# Altium schematic sheet Connectors_Peripherals.SchDoc(Connectors_Peripherals)
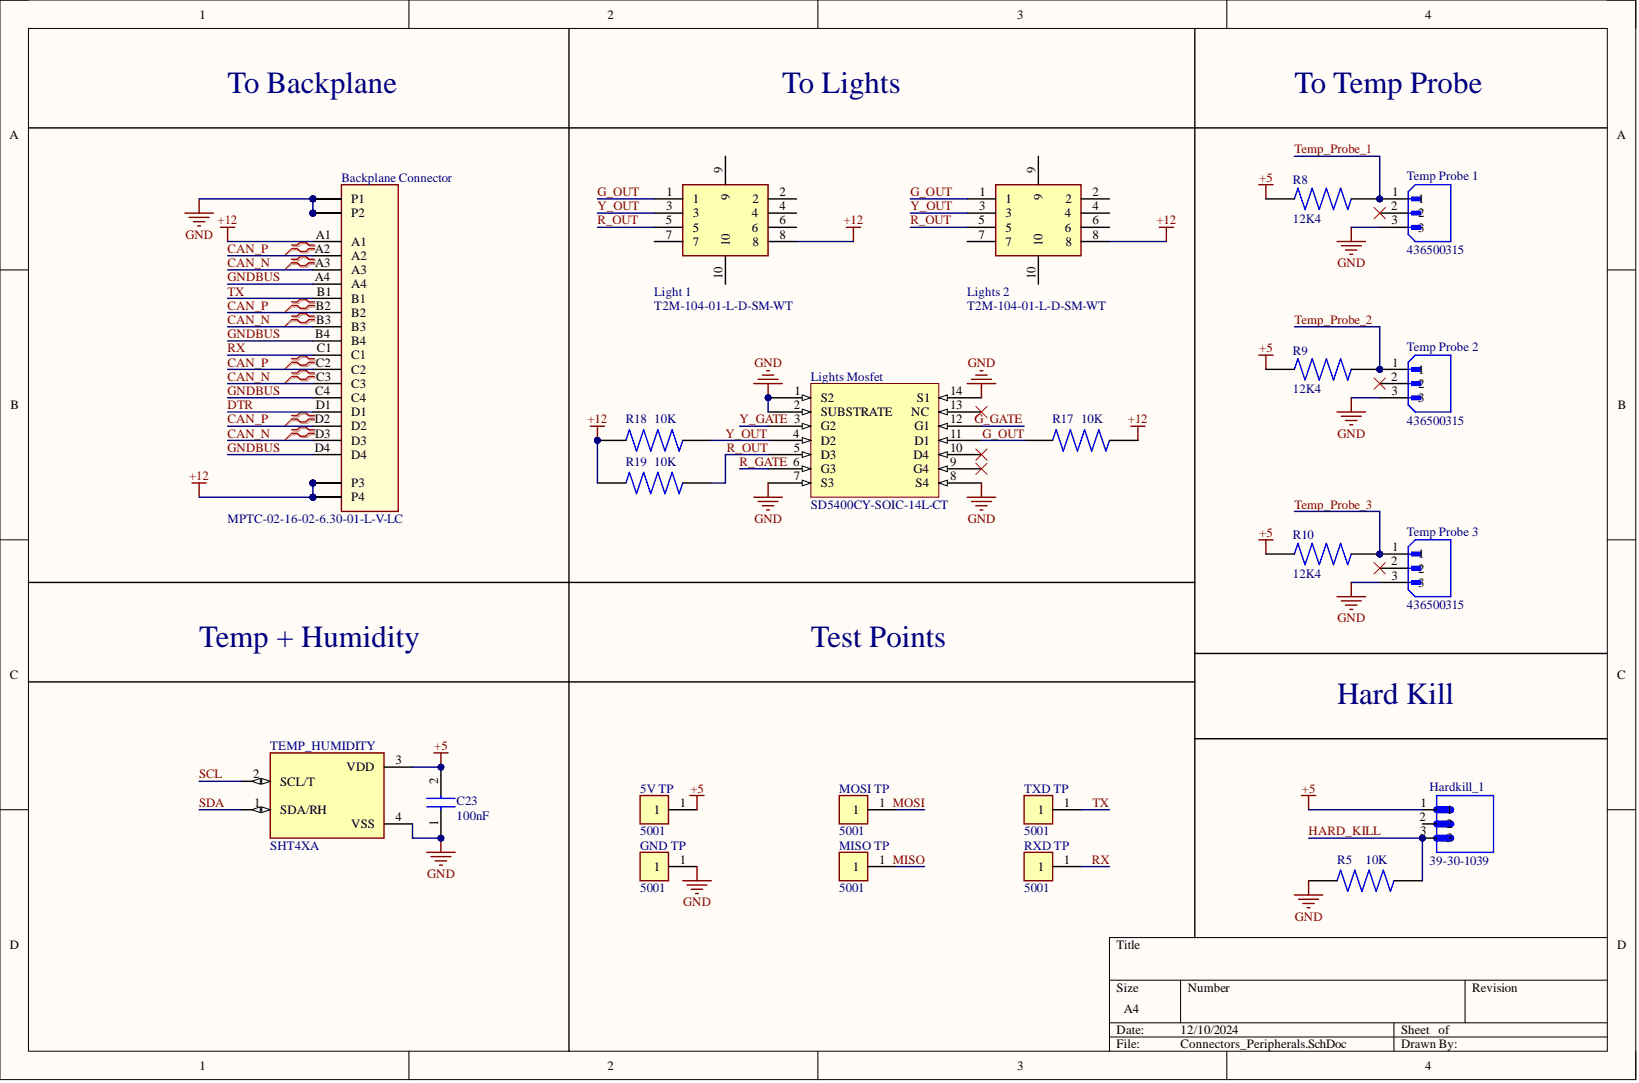
[diagram p0: Connectors_Peripherals.SchDoc]

## Parts
5V_TP  5001
Backplane_Connector  MPTC-02-16-02-6.30-01-L-V-LC
C23  100nF
GND_TP  5001
Hardkill_1  39-30-1039
Light_1  T2M-104-01-L-D-SM-WT
Lights_2  T2M-104-01-L-D-SM-WT
Lights_Mosfet  SD5400CY-SOIC-14L-CT
MISO_TP  5001
MOSI_TP  5001
R5  10K
R8  12K4
R9  12K4
R10  12K4
R17  10K
R18  10K
R19  10K
RXD_TP  5001
Temp_Probe_1  436500315
Temp_Probe_2  436500315
Temp_Probe_3  436500315
TEMP_HUMIDITY  SHT4XA
TXD_TP  5001

## Nets
+5: 5V_TP.1 C23.2 Hardkill_1.1 R8.1 R9.1 R10.1 TEMP_HUMIDITY.3(VDD)
+12: Backplane_Connector.A1 Backplane_Connector.P3.1(P3) Backplane_Connector.P3.2 Backplane_Connector.P3.3 Backplane_Connector.P3.4 Backplane_Connector.P3.5 Backplane_Connector.P3.6 Backplane_Connector.P4.1(P4) Backplane_Connector.P4.2 Backplane_Connector.P4.3 Backplane_Connector.P4.4 Backplane_Connector.P4.5 Backplane_Connector.P4.6 Light_1.8 Lights_2.8 R17.2 R18.1 R19.1
GND: Backplane_Connector.P1.1(P1) Backplane_Connector.P1.2 Backplane_Connector.P1.3 Backplane_Connector.P1.4 Backplane_Connector.P1.5 Backplane_Connector.P1.6 Backplane_Connector.P2.1(P2) Backplane_Connector.P2.2 Backplane_Connector.P2.3 Backplane_Connector.P2.4 Backplane_Connector.P2.5 Backplane_Connector.P2.6 C23.1 GND_TP.1 Lights_Mosfet.1(S2) Lights_Mosfet.2(SUBSTRATE) Lights_Mosfet.7(S3) Lights_Mosfet.8(S4) Lights_Mosfet.14(S1) R5.1 Temp_Probe_1.3 Temp_Probe_2.3 Temp_Probe_3.3 TEMP_HUMIDITY.4(VSS)
CAN_N: Backplane_Connector.A3 Backplane_Connector.B3 Backplane_Connector.C3 Backplane_Connector.D3
CAN_P: Backplane_Connector.A2 Backplane_Connector.B2 Backplane_Connector.C2 Backplane_Connector.D2
DTR: Backplane_Connector.D1
G_GATE: Lights_Mosfet.12(G1)
G_OUT: Light_1.1 Lights_2.1 Lights_Mosfet.11(D1) R17.1
GNDBUS: Backplane_Connector.A4 Backplane_Connector.B4 Backplane_Connector.C4 Backplane_Connector.D4
HARD_KILL: Hardkill_1.3 R5.2
MISO: MISO_TP.1
MOSI: MOSI_TP.1
R_GATE: Lights_Mosfet.6(G3)
R_OUT: Light_1.5 Lights_2.5 Lights_Mosfet.5(D3) R19.2
RX: Backplane_Connector.C1 RXD_TP.1
SCL: TEMP_HUMIDITY.2(SCL/T)
SDA: TEMP_HUMIDITY.1(SDA/RH)
Temp_Probe_1: R8.2 Temp_Probe_1.1
Temp_Probe_2: R9.2 Temp_Probe_2.1
Temp_Probe_3: R10.2 Temp_Probe_3.1
TX: Backplane_Connector.B1 TXD_TP.1
Y_GATE: Lights_Mosfet.3(G2)
Y_OUT: Light_1.3 Lights_2.3 Lights_Mosfet.4(D2) R18.2

Not connected: Hardkill_1 2; Light_1 2 4 6 7 9 10; Lights_2 2 4 6 7 9 10; Lights_Mosfet 9(G4) 10(D4) 13(NC); Temp_Probe_1 2; Temp_Probe_2 2; Temp_Probe_3 2

## Library data of the parts
5V_TP GND_TP MISO_TP MOSI_TP RXD_TP TXD_TP = CMP-2000-05181-2: PC TEST POINT MINIATURE BLACK; Body Material: Bronze; Hole Diameter: 1.6mm; Inside Diameter: 1.27mm; Insulation: Insulated; Number of Contacts: 1; Outside Diameter: 2.54mm; Plating: Silver; Color: Black; Component Kind: Standard; Contact Material: Bronze; Contact Plating: Silver; Diameter: 2.54mm; Flammability Rating: UL94 V-0; Height: 0.3inch; Housing Color: Black; Insulation Material: Nylon; Lead Free: Lead Free; Length: 7.62mm; Library Name: Altium Content Vault; Material: Nylon; Mount: Through Hole; Number of Ports: 1; Orientation: Straight; Package Quantity: 100; Packaging: Bulk; REACH SVHC: No SVHC; RoHS Compliant: Yes; Series: THM; Termination: Through Hole
Backplane_Connector = CMP-141-000007-3: Conn Power HDR 4Power/16Signal POS Solder ST Thru-Hole 40 Terminal 1 Port; Availability: Unavailable; Component Kind: Standard; Component Type: Standard; Design Item ID: MPTC-02-16-02-6.30-01-L-V-LC; MP: MPTC-02-16-02-6.30-01-L-V-LC; Package: None; Pin Count: 40; Price: None; Source: MPTC-02-16-02-6.30-01-L-V-LC.SchLib; Library Name: BumbleBee Autonomous Systems - NUS
C23 = CMP-061-000001-1: Capacitor; Manufacturer_Name: Wurth Elektronik; Manufacturer_Part_Number: 885012207098R; Component Kind: Standard; Datasheet Link: https://www.we-online.com/en/components/products/WCAP-CSGP-50VDC#885012207098R; Design Item ID: 885012207098R; Height: 0.9mm; Source: SamacSys.SchLib; Library Name: BumbleBee Autonomous Systems - NUS
Hardkill_1 = CMP-141-000020-1: Connector Header Through Hole 3 position 0.165 _4.20mm_; MF: Molex; MP: 39301039; Availability: Unavailable; Component Kind: Standard; Component Type: Standard; Design Item ID: 39-30-1039; Package: None; Pin Count: 3; Price: None; SnapEDA_Link: https://www.snapeda.com/parts/39301039/Molex/view-part/1160208/?ref=snap; Source: SnapEDAsch.SchLib; Library Name: BumbleBee Autonomous Systems - NUS
Light_1 Lights_2 = CMP-062-000001-1: Connector; Manufacturer_Name: SAMTEC; Arrow Part Number: T2M-104-01-L-D-SM-WT; Arrow Price/Stock: https://www.arrow.com/en/products/t2m-104-01-l-d-sm-wt/samtec; Component Kind: Standard; Datasheet Link: http://suddendocs.samtec.com/prints/t2m-1xx-xx-x-d-xx-xx-mkt.pdf; Design Item ID: T2M-104-01-L-D-SM-WT; Manufacturer_Part_Number: T2M-104-01-L-D-SM-WT; Mouser Part Number: 200-T2M10401LDSMWT; Mouser Price/Stock: https://www.mouser.com/Search/Refine.aspx?Keyword=200-T2M10401LDSMWT; Source: SamacSys.SchLib; Library Name: BumbleBee Autonomous Systems - NUS
Lights_Mosfet = CMP-00065-2: MOSFET Quad High Speed N-Channel Lateral DMOS JFET Switch With Zener Diode Protection; Component Kind: Standard; ComponentLink1Description: Component Link; ComponentLink1URL: https://www.mouser.sg/ProductDetail/Linear-Integrated-Systems/SD5400CY-SOIC-14L-CT?qs=T%252BzbugeAwjiENB9uQnJ51Q%3D%3D; ComponentLink2Description: Datasheet; ComponentLink2URL: https://www.mouser.sg/datasheet/2/1442/SD5000SeriesDSRevA12-3180024.pdf; Library Name: BumbleBee Autonomous Systems - NUS
R5 R17-R19 = CMP-147-000016-1: 10 kOhms Â±1% 0.125W, 1/8W Chip Resistor 0805 _2012 Metric_ Automotive AEC-Q200 Thick Film; MF: Vishay; MP: CRCW080510K0FKEA; Availability: Unavailable; Component Kind: Standard; Component Type: Standard; Design Item ID: CRCW080510K0FKEA; Package: 2012 Vishay; Pin Count: 2; Price: None; SnapEDA_Link: https://www.snapeda.com/parts/CRCW080510K0FKEA/Vishay%20Dale/view-part/47363/?ref=snap; Source: SnapEDAsch.SchLib; Library Name: BumbleBee Autonomous Systems - NUS
R8-R10 = CMP-147-000018-1: RES, THICK FILM, 4K7, 1%, 0.125W, 0805; MP: CRCW08054K70FKEA; Availability: Unavailable; Component Kind: Standard; Component Type: Standard; Design Item ID: CRCW08054K70FKEA; Package: None; Pin Count: 2; Price: None; Source: CRCW08054K70FKEA.SchLib; Library Name: BumbleBee Autonomous Systems - NUS
Temp_Probe_1-Temp_Probe_3 = CMP-315-000000-1: Availability: Unavailable; Component Kind: Standard; Component Type: Standard; Design Item ID: 436500315; MP: 436500315; Package: None; Pin Count: 3; Price: None; Source: 436500315.SchLib; Library Name: BumbleBee Autonomous Systems - NUS
TEMP_HUMIDITY = CMP-163-000000-1: Automotive Grade Relative Humidity Temperature Sensor, Â±3 %RH, Â±0.3 Â°C; MF: Sensirion; MP: SHT40A-AD1B-R3; Availability: Not in stock; Check_prices: https://www.snapeda.com/parts/SHT40A-AD1B-R3/Sensirion/view-part/?ref=eda; Component Kind: Standard; Component Type: Standard; Design Item ID: SHT4XA; Package: DFN-4 Sensirion; Pin Count: 4; Price: None; SnapEDA_Link: https://www.snapeda.com/parts/SHT40A-AD1B-R3/Sensirion/view-part/?ref=snap; Source: SHT4XA.SchLib; Library Name: BumbleBee Autonomous Systems - NUS
